# Altium schematic sheet 05_42688P+MS5611.SchDoc(05_42688P+MS5611)
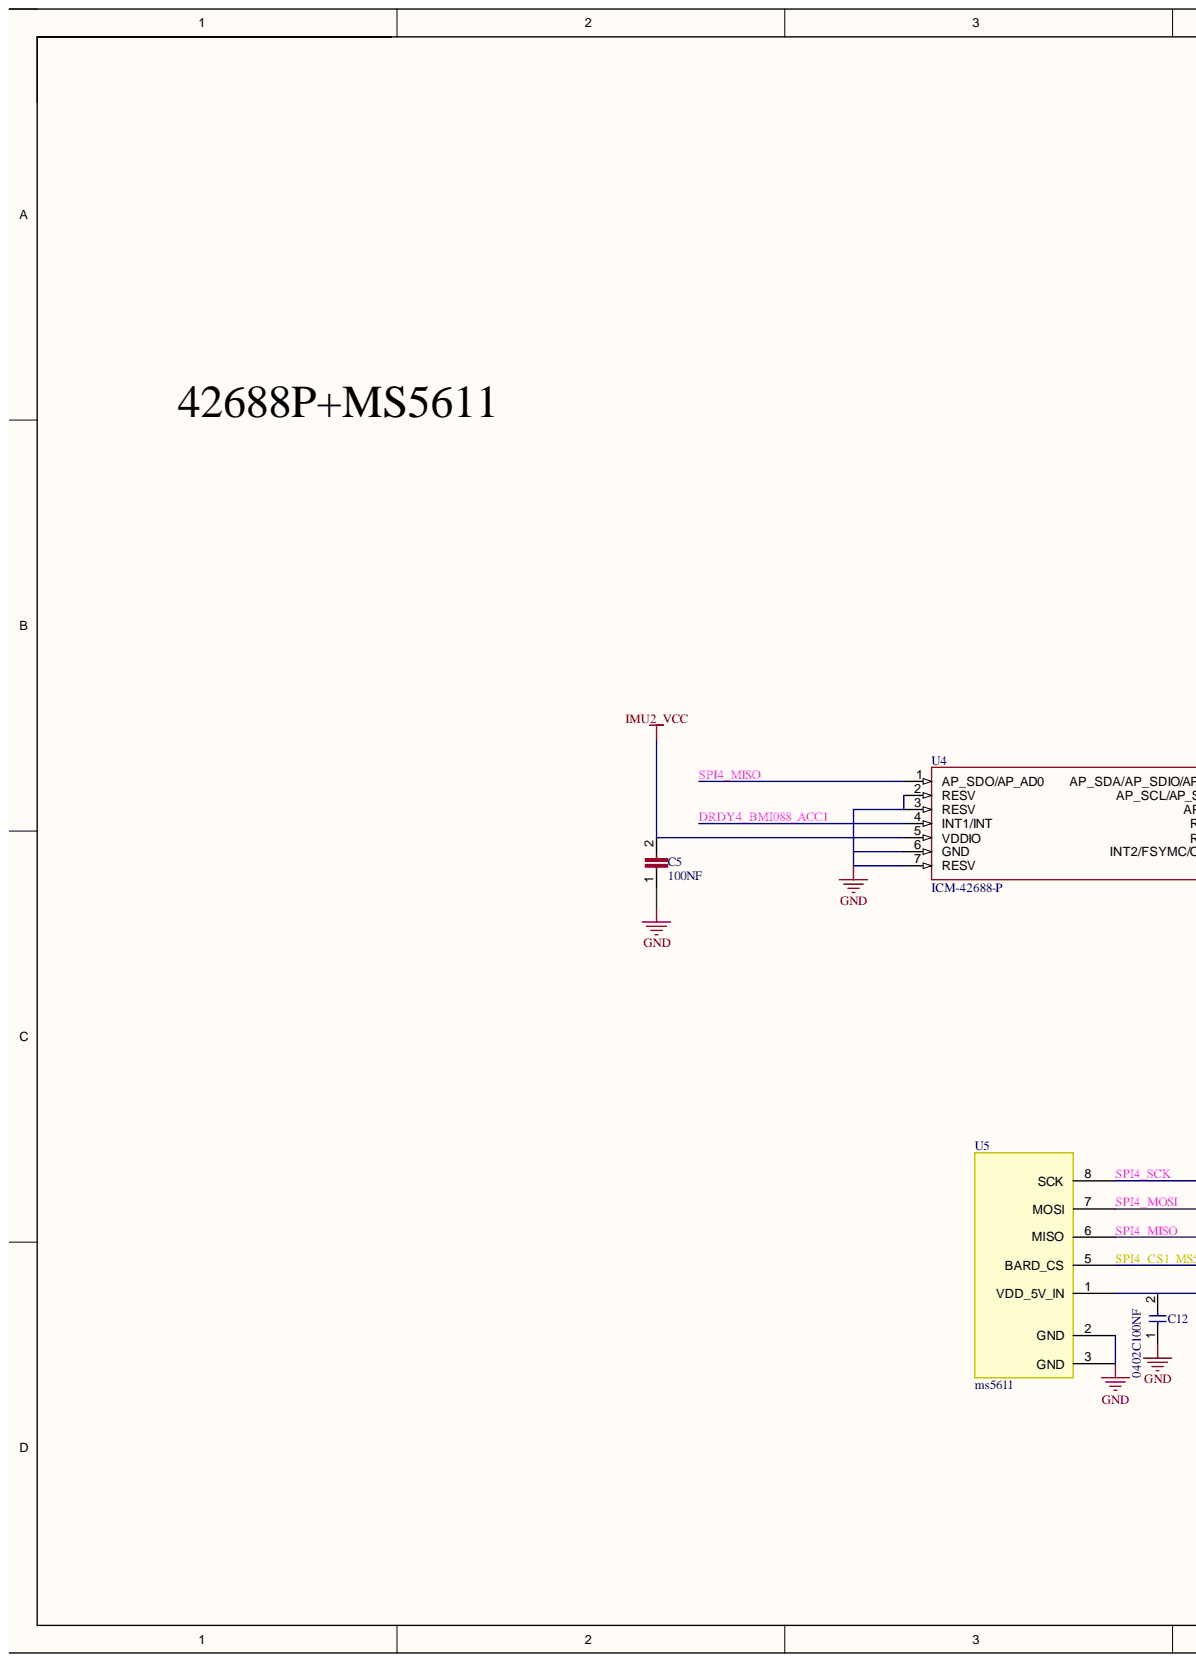
[diagram p0: 05_42688P+MS5611.SchDoc, left]
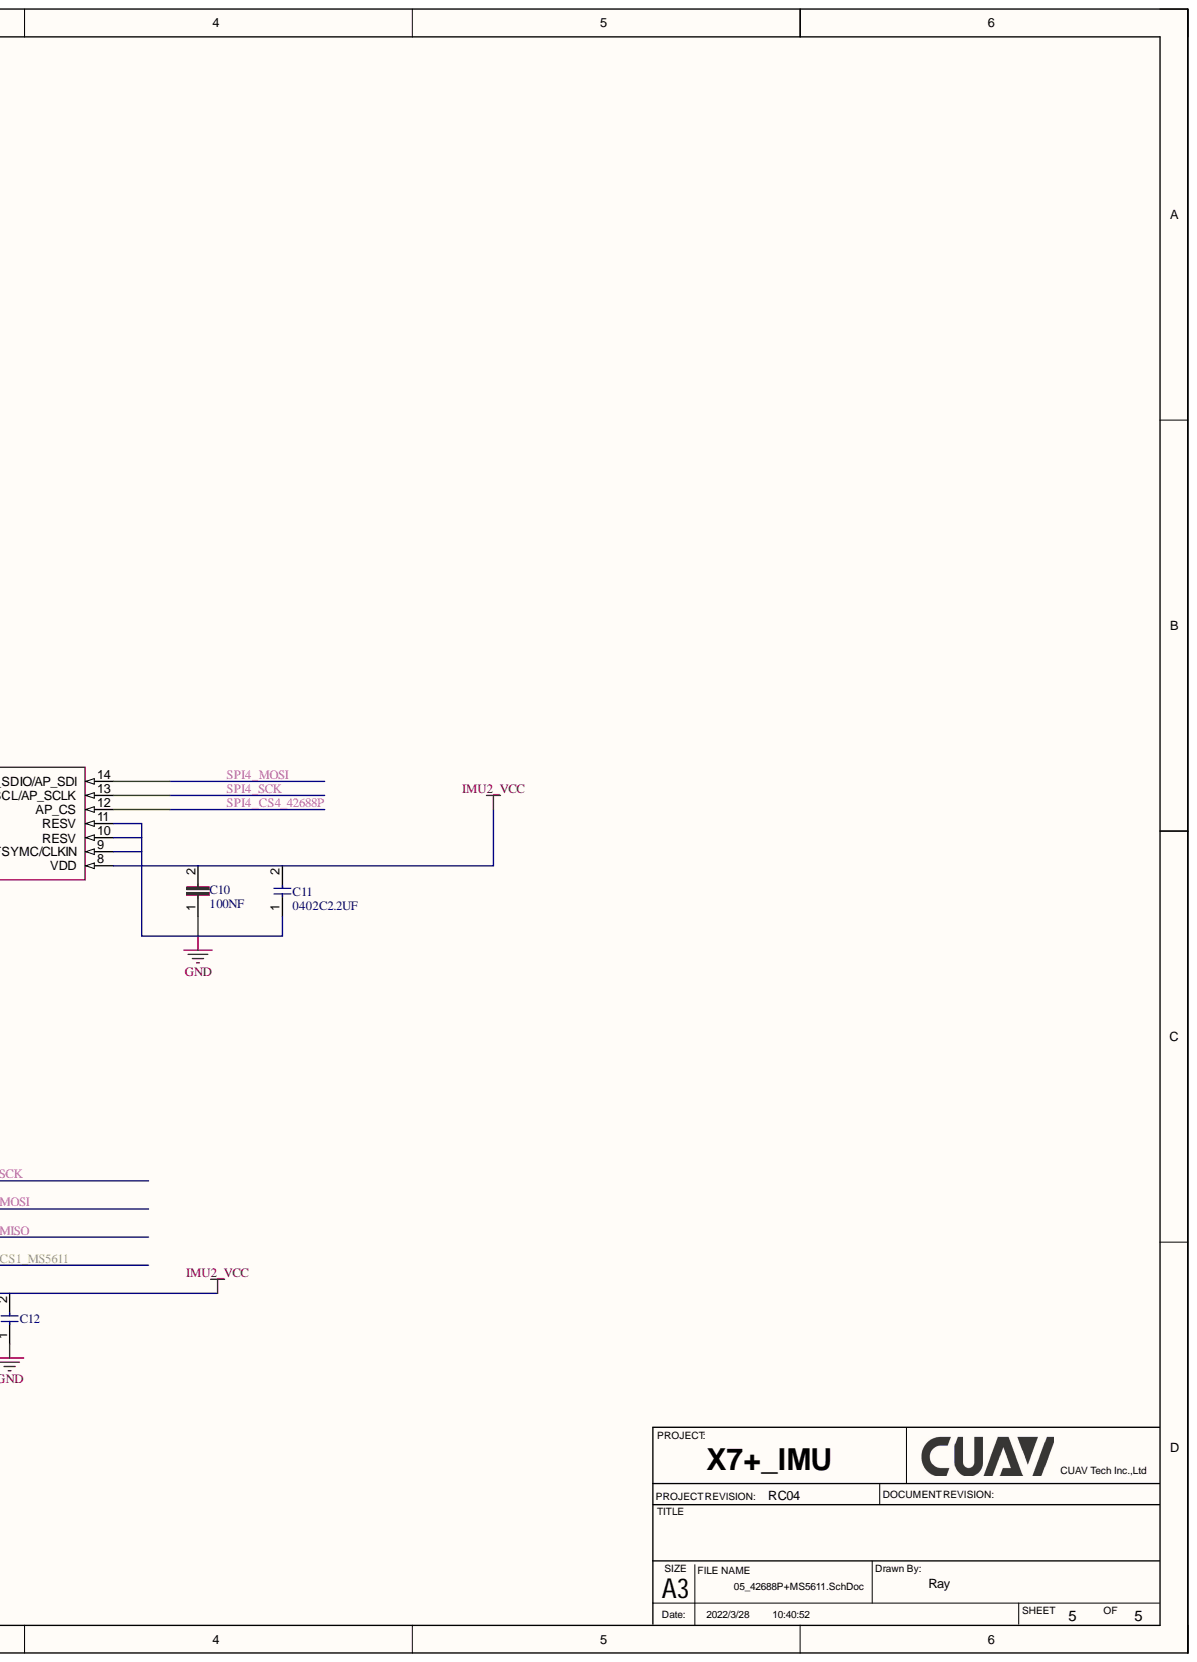
[diagram p1: 05_42688P+MS5611.SchDoc, right]

## Parts
C5  100NF  [0402C]
C10  100NF  [0402C]
C11  0402C2.2UF  [0402C]
C12  0402C100NF  [0402C]
U4  ICM-42688-P  [LGA2.5*3]
U5  ms5611  [ms5611]

## Nets
GND: C5.1 C10.1 C11.1 C12.1 U4.2(RESV) U4.3(RESV) U4.6(GND) U4.7(RESV) U4.9(INT2/FSYMC/CLKIN) U4.10(RESV) U4.11(RESV) U5.2(GND) U5.3(GND)
IMU2_VCC: C5.2 C10.2 C11.2 C12.2 U4.5(VDDIO) U4.8(VDD) U5.1(VDD_5V_IN)
DRDY4_BMI088_ACC1: U4.4(INT1/INT)
SPI4_CS1_MS5611: U5.5(BARD_CS)
SPI4_CS4_42688P: U4.12(AP_CS)
SPI4_MISO: U4.1(AP_SDO/AP_AD0) U5.6(MISO)
SPI4_MOSI: U4.14(AP_SDA/AP_SDIO/AP_SDI) U5.7(MOSI)
SPI4_SCK: U4.13(AP_SCL/AP_SCLK) U5.8(SCK)

## Library data of the parts
C5 C10 = 0402C100NF: 0402 CAP 100NF 50V 5%; Component Kind: Standard; Library Name: CUAV.SchLib
C11 = 0402C100NF: Component Kind: Standard; Library Name: CUAV.SchLib
C12 = 0402C100NF: 0402 100NF 10% 50V; Component Kind: Standard; Library Name: CUAV.SchLib
U4 = ICM-42688-P: Component Kind: Standard; Library Name: CUAV.SchLib
U5 = ms5611: Component Kind: Standard; Library Name: hack.SchLib
